# Altium schematic sheet 2. MICROBUS&Connectors.SchDoc("2. MICROBUS&Connectors")
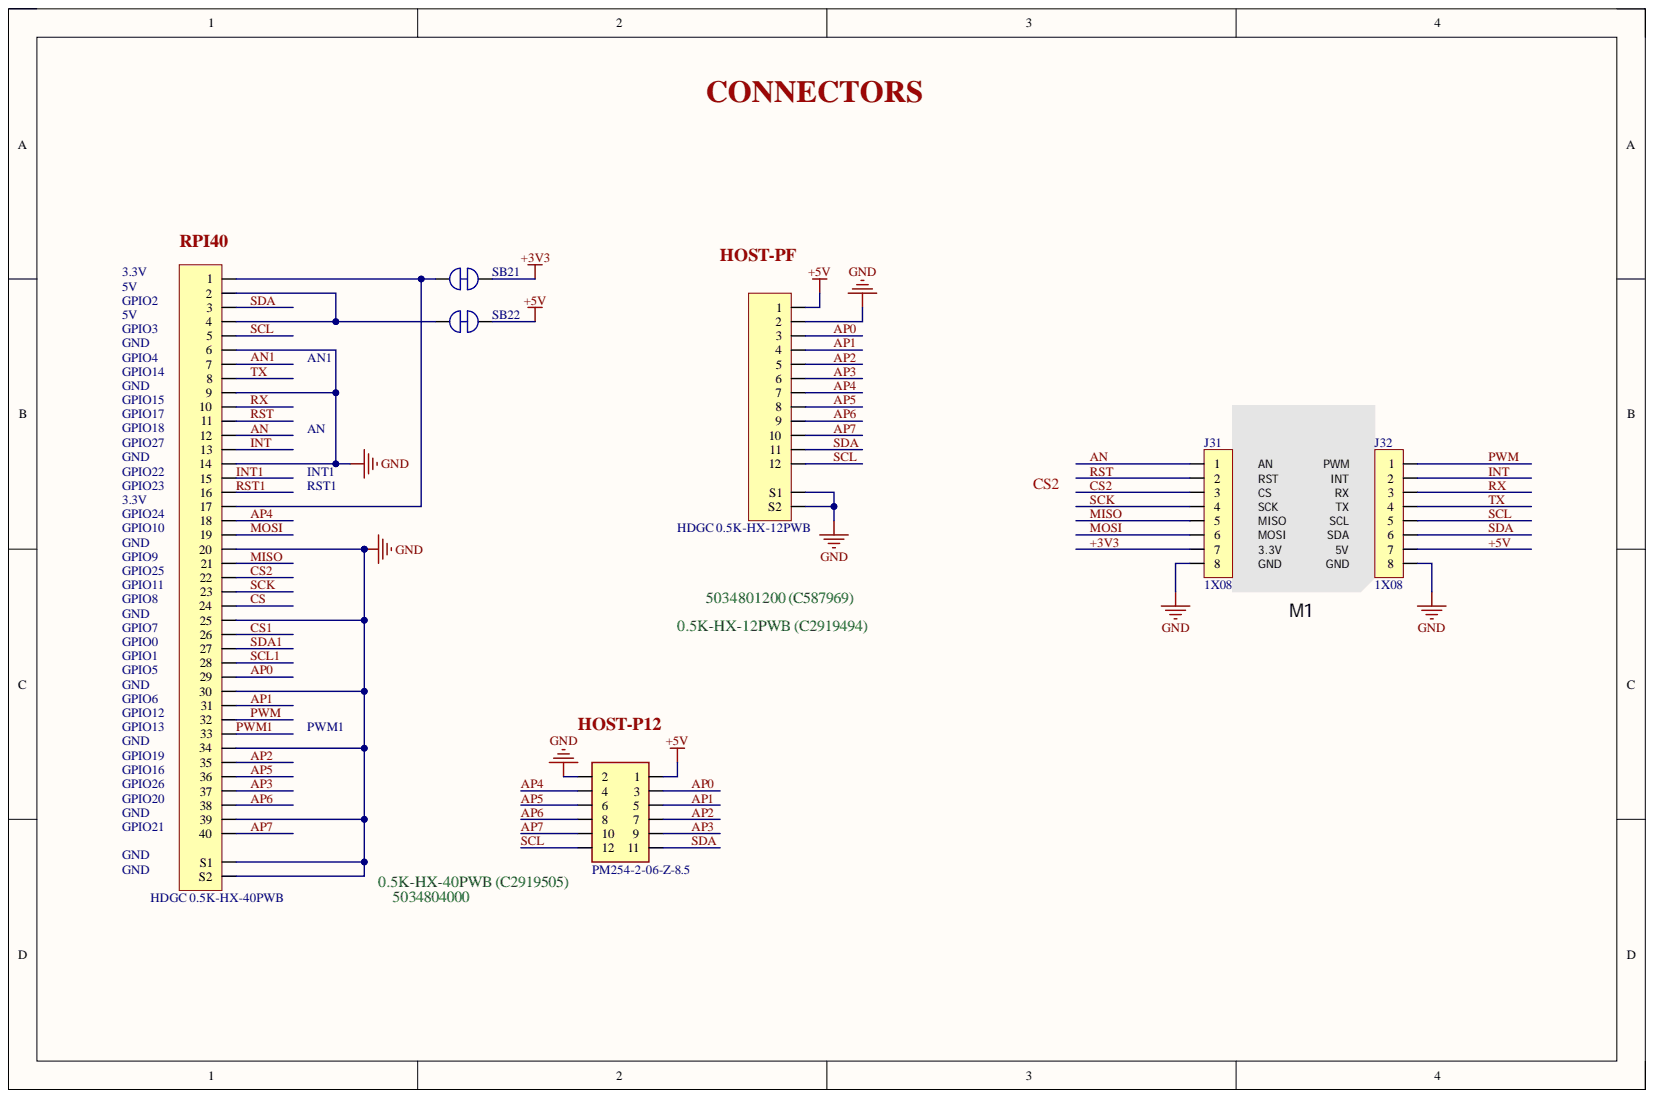
[diagram p0: 2. MICROBUS&Connectors.SchDoc]

## Parts
HOST-P12  PM254-2-06-Z-8.5  [PBD-12]
HOST-PF  HDGC 0.5K-HX-12PWB  [MOLX-503480-1200]
J31  1X08  [CON_1X08_2.54MM_FEMALE_TH_STRAIGHT]
J32  1X08  [CON_1X08_2.54MM_FEMALE_TH_STRAIGHT]
M1  MIKROBUS HOST  [MIKROBUS_HOST]  (value not drawn)  (no BOM)
RPI40  HDGC 0.5K-HX-40PWB  [FP-503480-4000-MFG]
SB21  SOLDER_JUMPER_NC  [SOLDER_JUMPER_NC]  (value not drawn)  (no BOM)
SB22  SOLDER_JUMPER_NC  [SOLDER_JUMPER_NC]  (value not drawn)  (no BOM)

## Nets
GND: HOST-P12.2 HOST-PF.2 HOST-PF.S1 HOST-PF.S2 J31.8 J32.8 RPI40.6 RPI40.9 RPI40.14 RPI40.20 RPI40.25 RPI40.30 RPI40.34 RPI40.39 RPI40.S1 RPI40.S2
+3V3: J31.7 SB21.2
+5V: HOST-P12.1 HOST-PF.1 J32.7 SB22.2
AN: J31.1 RPI40.12
AN1: RPI40.7
AP0: HOST-P12.3 HOST-PF.3 RPI40.29
AP1: HOST-P12.5 HOST-PF.4 RPI40.31
AP2: HOST-P12.7 HOST-PF.5 RPI40.35
AP3: HOST-P12.9 HOST-PF.6 RPI40.37
AP4: HOST-P12.4 HOST-PF.7 RPI40.18
AP5: HOST-P12.6 HOST-PF.8 RPI40.36
AP6: HOST-P12.8 HOST-PF.9 RPI40.38
AP7: HOST-P12.10 HOST-PF.10 RPI40.40
CS: RPI40.24
CS1: RPI40.26
CS2: J31.3 RPI40.22
INT: J32.2 RPI40.13
INT1: RPI40.15
MISO: J31.5 RPI40.21
MOSI: J31.6 RPI40.19
PWM: J32.1 RPI40.32
PWM1: RPI40.33
RST: J31.2 RPI40.11
RST1: RPI40.16
RX: J32.3 RPI40.10
SCK: J31.4 RPI40.23
SCL: HOST-P12.12 HOST-PF.12 J32.5 RPI40.5
SCL1: RPI40.28
SDA: HOST-P12.11 HOST-PF.11 J32.6 RPI40.3
SDA1: RPI40.27
TX: J32.4 RPI40.8
NetRPI40_1: RPI40.1 RPI40.17 SB21.1
NetRPI40_2: RPI40.2 RPI40.4 SB22.1

## Library data of the parts
HOST-P12 = DS1023-2x6SF11: 2.54mm Female Header  V/T Type, 2x06 Pin; Component Kind: Standard; Value: PM254-2-06-Z-8.5; Library Name: IoTextra_Input_2-03.SCHLIB
J31 J32 = CON_1X08_2.54MM_FEMALE_TH_STRAIGHT: 2.54mm Plugin 8P Straight 1x8P Plugin,P=2.54mm Female Headers ROHS; Manufacturer: BOOMELE(Boom Precision Elec); Manufacturer Part Number: C27438; Component Kind: Standard; Library Name: Schematic_Lib.SchLib; Supplier 1: LCSC; Supplier Part Number 1: C27438
M1 = MIKROBUS_HOST: mikroBUS Host Socket; Library Name: Schematic_Lib.SchLib
SB21 SB22 = SOLDER_JUMPER_NC: Solder Jumper; Library Name: Schematic_Lib.SchLib
HOST-PF = HDGC 0.5K-HX-12PWB: Component Kind: Standard; Description: HDGC 0.5K-HX-12PWB; Library Name: IoTextra_Input_2-03.SCHLIB
RPI40 = HDGC 0.5K-HX-40PWB: Component Kind: Standard; Description: HDGC 0.5K-HX-40PWB; Library Name: IoTextra_Input_2-03.SCHLIB
